# Altium schematic sheet pca20027 - power.SchDoc
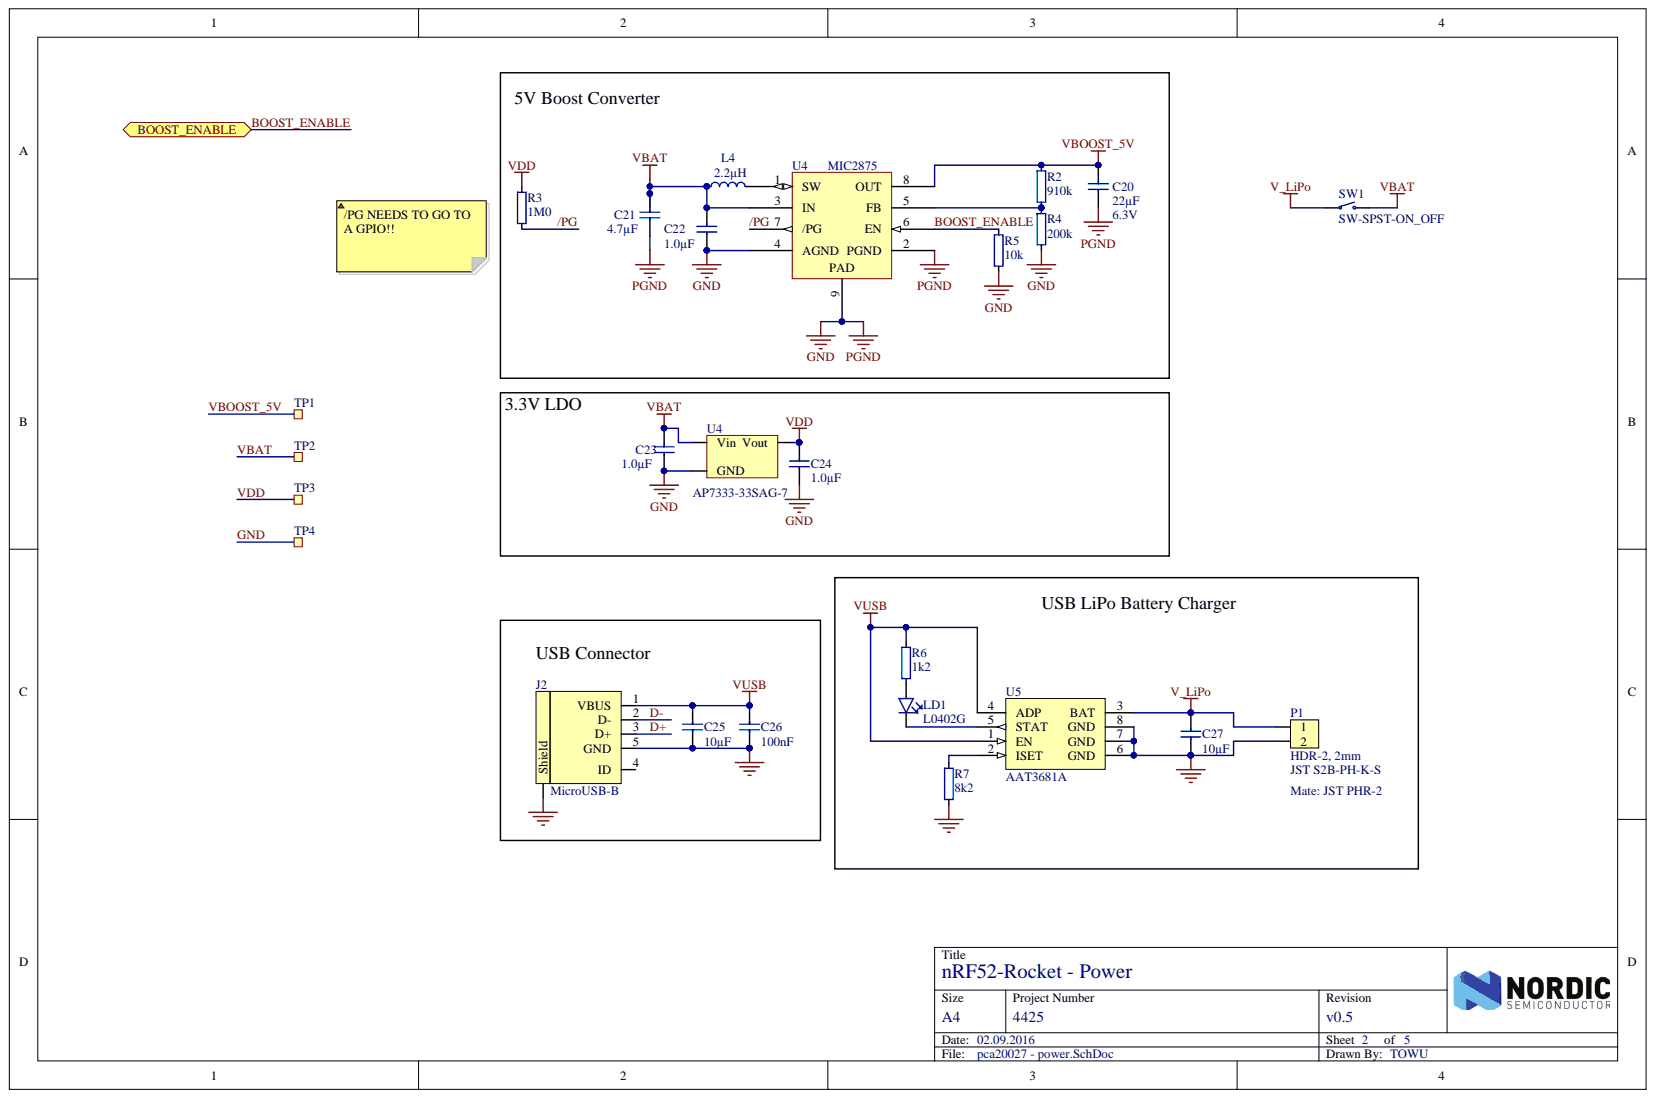
[diagram p0: pca20027 - power.SchDoc]

## Parts
C20  22µF  Note: 6.3V  [CAPC2012X11L]
C21  4.7µF  [CAPC1608X06L]
C22  1.0µF  [CAPC1608X06L]
C23  1.0µF  [CAPC1608X06L]
C24  1.0µF  [CAPC1608X06L]
C25  10µF  [CAPC1608X06L]
C26  100nF  [CAPC1608X06L]
C27  10µF  [CAPC1608X06L]
J2  MicroUSB-B  [USB-Micro-B-RA-SMD-PiP]
L4  2.2µH  [Coilcraft SRU5028]
LD1  L0402G  [LED_0402_G]
P1  HDR-2, 2mm  Manufacturer: JST  [S 2B-PH-K-S]
R2  910k  [RESC2012X11L]
R3  1M0  [RESC1608X06L]
R4  200k  [RESC2012X11L]
R5  10k  [RESC1608X06L]
R6  1k2  [RESC1608X06L]
R7  8k2  [RESC1608X06L]
SW1  SW-SPST-ON_OFF  [GF-1123-0009]
TP1  Ø1.0mm,SMD  [TP_SMD_Ø1.0mm]  (value not drawn)  (no BOM)
TP2  Ø1.0mm,SMD  [TP_SMD_Ø1.0mm]  (value not drawn)  (no BOM)
TP3  Ø1.0mm,SMD  [TP_SMD_Ø1.0mm]  (value not drawn)  (no BOM)
TP4  Ø1.0mm,SMD  [TP_SMD_Ø1.0mm]  (value not drawn)  (no BOM)
U4  MIC2875  [MIC2875]
U4  AP7333-33SAG-7  [SOT-23A]
U5  AAT3681A  [SOJ50P220X110-8N]

## Ports
BOOST_ENABLE

## Nets
V_LIPO: C27.2 P1.1 SW1.1 U5.3(BAT)
VUSB: C25.1 C26.2 J2.1(VBUS) R6.2 U5.1(EN) U5.4(ADP)
/PG: R3.1 U4.7(/PG)
BOOST_ENABLE: R5.2 U4.6(EN) ; port BOOST_ENABLE
D+: J2.3(D+)
D-: J2.2(D-)
GND: C20.1 C21.1 C22.1 C23.2 C24.1 C25.2 C26.1 C27.1 J2.5(GND) J2.6(Shield) P1.2 R4.1 R5.1 R7.1 TP4.1 U4.2 U4.3 U4.4(AGND) U4.9 U5.6(GND) U5.7(GND) U5.8(GND)
VBAT: C21.2 C22.2 C23.1 L4.1 SW1.2 TP2.1 U4.1 U4.3
VBOOST_5V: C20.2 R2.2 TP1.1 U4.8(OUT)
VDD: C24.2 R3.2 TP3.1 U4.2
NetL4_2: L4.2 U4.1
NetLD1_1: LD1.1 U5.5(STAT)
NetLD1_2: LD1.2 R6.1
NetR2_1: R2.1 R4.2 U4.5(FB)
NetR7_2: R7.2 U5.2(ISET)

Not connected: J2 4(ID)

## Library data of the parts
C20 = C0805-22µ: Capacitor, X5R, ±10%; Artikkelnr Noca: 20039330; Artikkelnr Simpro: N.A.; Database Table Name: Capacitors$; Component Kind: Standard; Footprint Doc: 0805; LatestRevisionDate: 2013-06-04; Library Name: Nordic Passive DB.DbLib; Part Number: C0805-22µ; Pin Count: 2; Published: 2011-01-17; Value: 22µF; Publisher: Nordic Semiconductor
C21 = C0603-4µ7: Capacitor, X5R, ±10%; Artikkelnr Noca: 20069060; Artikkelnr Simpro: N.A.; Database Table Name: Capacitors$; Note: 6.3V; Component Kind: Standard; Footprint Doc: 0603; LatestRevisionDate: 2009-11-23; Library Name: Nordic Passive DB.DbLib; Part Number: C0603-4µ7; Pin Count: 2; Published: 2009-05-08; Value: 4.7µF; Publisher: Nordic Semiconductor
C22-C24 = C0603-1µ0: Capacitor, X7R, ±10%; Artikkelnr Noca: 20039335; Artikkelnr Simpro: N.A.; Database Table Name: Capacitors$; Note: 16V; Component Kind: Standard; Footprint Doc: 0603; LatestRevisionDate: 2013-06-05; Library Name: Nordic Passive DB.DbLib; Part Number: C0603-1µ0; Pin Count: 2; Published: 2009-05-08; Value: 1.0µF; Publisher: Nordic Semiconductor
C25 C27 = C0603-10µ: Capacitor, X5R, ±20%; Artikkelnr Noca: 20039234; Artikkelnr Simpro: N.A.; Database Table Name: Capacitors$; Note: 6.3V; Component Kind: Standard; Footprint Doc: 0603; LatestRevisionDate: 2011-01-17; Library Name: Nordic Passive DB.DbLib; Part Number: C0603-10µ; Pin Count: 2; Published: 2011-01-17; Value: 10µF; Publisher: Nordic Semiconductor
C26 = C0603-100n: Chip Cap 0603; Artikkelnr Noca: N.A.; Database Table Name: Capacitors$; Note: Chip Cap 1%; Artikkelnr Simpro: N.A.; Component Kind: Standard; Footprint Doc: 0603; LatestRevisionDate: 2009-05-08; Library Name: Nordic Passive DB.DbLib; Part Number: C0603-100n; Pin Count: 2; Published: 2009-05-08; Value: 100nF; Publisher: Nordic Semiconductor
J2 = USB-Micro-B-RA-SMD-PiP: MicroUSB-B-SMT; 2nd source part number: 609-4616-1-ND; Manufacturer: Allen Creations Corp.; Manufacturer Part Number: AJU55-BS2111-L; Artikkelnr Noca: 55029020; Artikkelnr Simpro: N.A.; Database Table Name: Connectors$; 2nd source Supplier: Digi-Key; Component Kind: Standard; Datasheet: \\hizz\pro\app9717\Datasheet\connectores\Allen Creations Corp\AJU-211.pdf; Footprint Doc: USB-Micro-B-RA-SMD-PiP; LatestRevisionDate: 2016-04-21; Library Name: Nordic Passive DB.DbLib; Part Number: USB-Micro-B-RA-SMD-PiP; Pin Count: 5; Published: 2014-10-07; Supplier: Cabcon; Supplier part number: AJU55-BS2111-L; Value: MicroUSB-B; Publisher: Nordic Semiconductor
L4 = SRU5028-2R2Y: FIXED IND 2.2UH 3.2A 21 MOHM SMD; Manufacturer: Bourns; Artikkelnr Noca: N.A.; Database Table Name: Inductors$; Artikkelnr Simpro: N.A.; Component Kind: Standard; Datasheet: http://www.bourns.com/docs/Product-Datasheets/SRU5028.pdf; Footprint Doc: SRU5028; LatestRevisionDate: 2015-10-21; Library Name: Nordic Passive DB.DbLib; Manufacturer Part Number: SRU5028-2R2Y; Part Number: SRU5028-2R2Y; Pin Count: 2; Published: 2015-10-21; Supplier: Digi-Key; Supplier part number: SRU5028-2R2YCT-ND; Value: 2.2µH; Publisher: Nordic Semiconductor
LD1 = APHHS1005CGCK: LED, Green, 0402, Vf=2.1V, 50mcd, - 40 to +85°C; Manufacturer: Kingbright Company LLC; Artikkelnr Noca: N.A.; Database Table Name: Optoelectronics$; Artikkelnr Simpro: N.A.; Component Kind: Standard; Datasheet: http://www.kingbrightusa.com/images/catalog/SPEC/APHHS1005CGCK.pdf; Footprint Doc: 0402; LatestRevisionDate: 2015-12-23; Library Name: Nordic Discrete DB.DbLib; Manufacturer Part Number: APHHS1005CGCK; Part Number: APHHS1005CGCK; Pin Count: 2; Published: 2015-12-23; Supplier: Digi-Key; Supplier part number: 754-1101-1-ND; Value: L0402G; Publisher: Nordic Semiconductor
P1 = S2B-PH-K-S: JST-S2B-PH-K-S (LF)(SN)-HEADER-SIDE ENTRY, 2WAY; Artikkelnr Noca: N.A.; Database Table Name: Connectors$; Note: JST, Header; Artikkelnr Simpro: N.A.; Component Kind: Standard; Datasheet: http://www.farnell.com/datasheets/80564.pdf; Footprint Doc: S 2B-PH-K-S; LatestRevisionDate: 2010-02-02; Library Name: Nordic Passive DB.DbLib; Manufacturer Part Number: S2B-PH-K-S (LF)(SN); Part Number: S2B-PH-K-S; Pin Count: 2; Published: 2010-02-02; Supplier: Farnell; Supplier part number: 949-1902; Value: HDR-2, 2mm; Publisher: Nordic Semiconductor
R2 = R0805- 910K_0.1%: Resistor, ±0.1%, 0.125W; Manufacturer: Panasonic; Manufacturer Part Number: ERA-6AEB914V; Artikkelnr Noca: N.A.; Database Table Name: Resistors$; Note: Chip Res 0.1%; Value Ohm: 910000; Artikkelnr Simpro: N.A.; Component Kind: Standard; Datasheet: http://media.digikey.com/pdf/Data%20Sheets/Panasonic%20Electronic%20Components/ERA%201A,2A,3A,6A,8A.pdf; Footprint Doc: 0805; LatestRevisionDate: 2016-07-01; Library Name: Nordic Passive DB.DbLib; Part Number: R0805- 910K_0.1%; Pin Count: 2; Published: 2016-07-01; Supplier: Digi-Key; Supplier part number: P910KDACT-ND; Value: 910k; Publisher: Nordic Semiconductor
R3 = R0603-1M0: Resistor, ±1%, 0.06W; Artikkelnr Noca: 27069145; Artikkelnr Simpro: N.A.; Database Table Name: Resistors$; Note: Chip Res 1%; Value Ohm: 1000000; Component Kind: Standard; Footprint Doc: 0603; LatestRevisionDate: 2011-08-16; Library Name: Nordic Passive DB.DbLib; Part Number: R0603-1M0; Pin Count: 2; Published: 2009-05-08; Value: 1M0; Publisher: Nordic Semiconductor
R4 = R0805- 200K_0.1%: Resistor, ±0.1%, 0.125W; Manufacturer: Panasonic; Manufacturer Part Number: ERA-6AEB204V; Artikkelnr Noca: N.A.; Database Table Name: Resistors$; Note: Chip Res 0.1%; Value Ohm: 200000; Artikkelnr Simpro: N.A.; Component Kind: Standard; Datasheet: http://media.digikey.com/pdf/Data%20Sheets/Panasonic%20Electronic%20Components/ERA%201A,2A,3A,6A,8A.pdf; Footprint Doc: 0805; LatestRevisionDate: 2016-07-01; Library Name: Nordic Passive DB.DbLib; Part Number: R0805- 200K_0.1%; Pin Count: 2; Published: 2016-07-01; Supplier: Digi-Key; Supplier part number: P200KDACT-ND; Value: 200k; Publisher: Nordic Semiconductor
R5 = R0603-10k: Resistor, ±1%, 0.1W; Artikkelnr Noca: 27069097; Artikkelnr Simpro: N.A.; Database Table Name: Resistors$; Note: Chip Res 1%; Value Ohm: 10000; Component Kind: Standard; Footprint Doc: 0603; LatestRevisionDate: 2011-08-16; Library Name: Nordic Passive DB.DbLib; Part Number: R0603-10k; Pin Count: 2; Published: 2009-05-08; Value: 10k; Publisher: Nordic Semiconductor
R6 = R0603-1k2: Resistor, ±1%, 0.06W; Artikkelnr Noca: 27069075; Artikkelnr Simpro: N.A.; Database Table Name: Resistors$; Note: Chip Res 1%; Value Ohm: 1200; Component Kind: Standard; Footprint Doc: 0603; LatestRevisionDate: 2011-08-16; Library Name: Nordic Passive DB.DbLib; Part Number: R0603-1k2; Pin Count: 2; Published: 2009-05-08; Value: 1k2; Publisher: Nordic Semiconductor
R7 = R0603-8k2: Resistor, ±1%, 0.06W; Artikkelnr Noca: 27069095; Artikkelnr Simpro: N.A.; Database Table Name: Resistors$; Note: Chip Res 1%; Value Ohm: 8200; Component Kind: Standard; Footprint Doc: 0603; LatestRevisionDate: 2011-08-16; Library Name: Nordic Passive DB.DbLib; Part Number: R0603-8k2; Pin Count: 2; Published: 2009-05-08; Value: 8k2; Publisher: Nordic Semiconductor
SW1 = GF-1123-0009: Slide Switch SPST  125V, 500mA DC, 11A AC; Manufacturer: CW Industries; Artikkelnr Noca: N.A.; Database Table Name: Rele_Switch$; Artikkelnr Simpro: N.A.; Component Kind: Standard; Datasheet: http://www.cwind.com/pdf/Standard-Switch-Catalog-S2120-II-03-2.pdf; Footprint Doc: GF-1123-0009; LatestRevisionDate: 2016-07-07; Library Name: Nordic Discrete DB.DbLib; Manufacturer Part Number: GF-1123-0009; Part Number: GF-1123-0009; Pin Count: 2; Published: 2016-07-07; Supplier: Digi-Key; Supplier part number: GF-1123-0009; Value: SW-SPST; Publisher: Nordic Semiconductor
TP1-TP4 = TP_SMD_Ø1.0MM: 1.0mm circular SMD testpad; Manufacturer: Nordic Semiconductor; Artikkelnr Noca: N.A.; Database Table Name: Connectors$; Artikkelnr Simpro: N.A.; Footprint Doc: TP_SMD_Ø1.0mm; LatestRevisionDate: 2013-06-11; Library Name: Nordic Passive DB.DbLib; Part Number: TP_SMD_Ø1.0MM; Pin Count: 1; Published: 2013-06-11; Value: Ø1.0mm,SMD; Publisher: Nordic Semiconductor
U4 = MIC2875: 4.8A Synchronous Boost Regulator with Bi-Directional Load Disconnect; Manufacturer: Microchip; Artikkelnr Noca: N.A.; Database Table Name: Misc IC$; Artikkelnr Simpro: N.A.; Component Kind: Standard; Datasheet: http://ww1.microchip.com/downloads/en/DeviceDoc/20005549A.pdf; Footprint Doc: MIC2875; LatestRevisionDate: 2016-06-28; Library Name: Nordic Active DB.DbLib; Manufacturer Part Number: MIC2875; Part Number: MIC2875; Pin Count: 8; Published: 2016-06-22; Supplier: Digi-Key; Supplier part number: 576-4863-1-ND; Value: MIC2875; Publisher: Nordic Semiconductor
U4 = AP7333-33SAG-7: 300mA, Low Quiescent Current, Fast Transient Low Dropout Linear Regulator; Manufacturer: Diodes Incorporated; Artikkelnr Noca: 17039216; Artikkelnr Simpro: N.A.; Database Table Name: Misc IC$; Component Kind: Standard; Datasheet: http://www.diodes.com/datasheets/AP7333.pdf; Footprint Doc: SOT-23-3; LatestRevisionDate: 2012-06-15; Library Name: Nordic Active DB.DbLib; Manufacturer Part Number: AP7333-33SAG-7; Part Number: AP7333-33SAG-7; Pin Count: 3; Published: 2012-06-15; Supplier: Digi-Key; Supplier part number: AP7333-33SAG-7DICT-ND; Value: AP7333-33SAG-7; Publisher: Nordic Semiconductor
U5 = AAT3681AIJS-4.2-T1: 500mA USB Port or AC Adapter Li-Ion/Polymer Battery Charger; Manufacturer: Skyworks; Artikkelnr Noca: N.A.; Database Table Name: Misc IC$; Artikkelnr Simpro: N.A.; Component Kind: Standard; Datasheet: http://www.skyworksinc.com/uploads/documents/AAT3681A_201883C.pdf; Footprint Doc: TFSOJ-8; LatestRevisionDate: 2015-12-22; Library Name: Nordic Active DB.DbLib; Manufacturer Part Number: AAT3681AIJS-4.2-T1; Part Number: AAT3681AIJS-4.2-T1; Pin Count: 8; Published: 2015-12-22; Supplier: Digi-Key; Supplier part number: 863-1510-1-ND; Value: AAT3681A; Publisher: Nordic Semiconductor
